# Altium schematic sheet Connectors.SchDoc(Connectors)
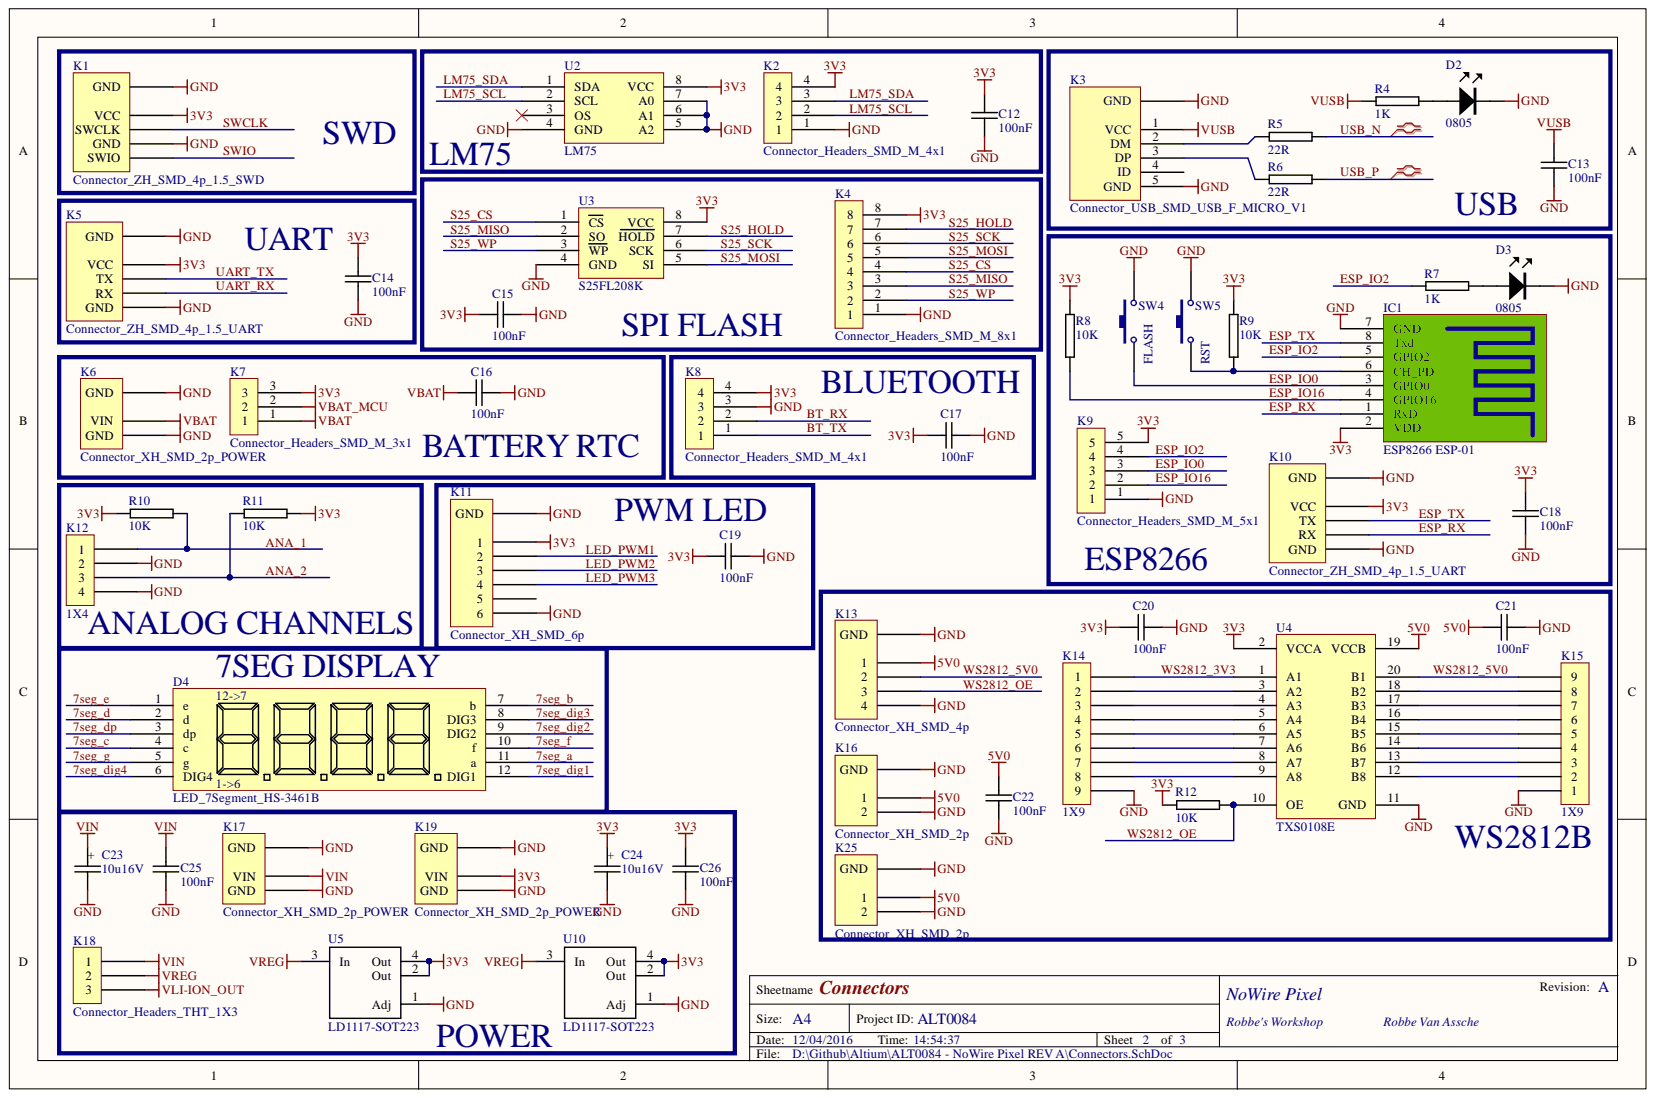
[diagram p0: Connectors.SchDoc]

## Parts
C12  100nF  [C0402]
C13  100nF  [C0402]
C14  100nF  [C0402]
C15  100nF  [C0402]
C16  100nF  [C0402]
C17  100nF  [C0402]
C18  100nF  [C0402]
C19  100nF  [C0402]
C20  100nF  [C0402]
C21  100nF  [C0402]
C22  100nF  [C0402]
C23  10u16V  [1206C+_TANTAL-10u16V]
C24  10u16V  [1206C+_TANTAL-10u16V]
C25  100nF  [C0402]
C26  100nF  [C0402]
D2  0805  [0805LED]
D3  0805  [0805LED]
D4  LED_7Segment_HS-3461B  [HS3461-TH]
IC1  ESP8266 ESP-01  [ESP8266 ESP-01]
K1  Connector_ZH_SMD_4p_1.5_SWD  [ZH_SMD_4p_1.5]
K2  Connector_Headers_SMD_M_4x1  [Header_Male_2.54 4x1_COMBO]
K3  Connector_USB_SMD_USB_F_MICRO_V1  [USB_F_Micro_V1]
K4  Connector_Headers_SMD_M_8x1  [Header_Male_2.54 8x1_COMBO]
K5  Connector_ZH_SMD_4p_1.5_UART  [ZH_SMD_4p_1.5]
K6  Connector_XH_SMD_2p_POWER  [2.54_2p_THT+SMD]
K7  Connector_Headers_SMD_M_3x1  [Header_Male_2.54 3x1_COMBO]
K8  Connector_Headers_SMD_M_4x1  [Header_Male_2.54 4x1_COMBO]
K9  Connector_Headers_SMD_M_5x1  [Header_Male_2.54 5x1_COMBO]
K10  Connector_ZH_SMD_4p_1.5_UART  [ZH_SMD_4p_1.5]
K11  Connector_XH_SMD_6p  [2.54_6p]
K12  1X4  [HEADER-1X4]
K13  Connector_XH_SMD_4p  [2.54_4p]
K14  1X9  [HEADER-1X9]
K15  1X9  [HEADER-1X9]
K16  Connector_XH_SMD_2p  [2.54_2p]
K17  Connector_XH_SMD_2p_POWER  [2.54_2p_THT+SMD]
K18  Connector_Headers_THT_1X3  [HEADER-1X3]
K19  Connector_XH_SMD_2p_POWER  [2.54_2p_THT+SMD]
K25  Connector_XH_SMD_2p  [2.54_2p]
R4  1K  [R0402]
R5  22R  [R0402]
R6  22R  [R0402]
R7  1K  [R0402]
R8  10K  [R0402]
R9  10K  [R0402]
R10  10K  [R0402]
R11  10K  [R0402]
R12  10K  [R0402]
SW4  FLASH  [1P1T_SW_5x5]
SW5  RST  [1P1T_SW_5x5]
U2  LM75  [SO8_N]
U3  S25FL208K  [SO8_N]
U4  TXS0108E  [TSSOP20]
U5  LD1117-SOT223  [SOT223]
U10  LD1117-SOT223  [SOT223]

## Nets
3V3: C12.1 C14.1 C15.1 C17.1 C18.1 C19.1 C20.1 C24.1 C26.1 IC1.2(VDD) K1.1(VCC) K2.4 K4.8 K5.1(VCC) K7.3 K8.4 K9.5 K10.1(VCC) K11.1 K19.1 R8.2 R9.2 R10.2 R11.2 R12.2 U2.8(VCC) U3.8(VCC) U4.2(VCCA) U5.2(Out) U5.4(Out) U10.2(Out) U10.4(Out)
5V0: C21.1 C22.1 K13.1 K16.1 K25.1 U4.19(VCCB)
GND: C12.2 C13.2 C14.2 C15.2 C16.2 C17.2 C18.2 C19.2 C20.2 C21.2 C22.2 C23.2 C24.2 C25.2 C26.2 D2.K D3.K IC1.7(GND) K1.0(GND) K1.3(GND) K2.1 K3.0(GND) K3.5(GND) K4.1 K5.0(GND) K5.4(GND) K6.0 K6.2 K8.3 K9.1 K10.0(GND) K10.4(GND) K11.0(GND) K11.6 K12.2 K12.4 K13.0(GND) K13.4 K14.9 K15.1 K16.0 K16.2 K17.0 K17.2 K19.0 K19.2 K25.0 K25.2 SW4.2 SW5.2 U2.4(GND) U2.5(A2) U2.6(A1) U2.7(A0) U3.4(GND) U4.11(GND) U5.1(Adj) U10.1(Adj)
VBAT: C16.1 K6.1 K7.1
VBAT_MCU: K7.2
VIN: C23.1 C25.1 K17.1 K18.1
VLI-ION_OUT: K18.3
VREG: K18.2 U5.3(In) U10.3(In)
VUSB: C13.1 K3.1(VCC) R4.1
7SEG_A: D4.11(a)
7SEG_B: D4.7(b)
7SEG_C: D4.4(c)
7SEG_D: D4.2(d)
7SEG_DIG1: D4.12(DIG1)
7SEG_DIG2: D4.9(DIG2)
7SEG_DIG3: D4.8(DIG3)
7SEG_DIG4: D4.6(DIG4)
7SEG_DP: D4.3(dp)
7SEG_E: D4.1(e)
7SEG_F: D4.10(f)
7SEG_G: D4.5(g)
ANA_1: K12.1 R10.1
ANA_2: K12.3 R11.1
BT_RX: K8.2
BT_TX: K8.1
ESP_IO0: IC1.3(GPIO0) K9.3 SW4.1
ESP_IO2: IC1.5(GPIO2) K9.4 R7.1
ESP_IO16: IC1.4(GPIO16) K9.2 R8.1
ESP_RX: IC1.1(RxD) K10.3(RX)
ESP_TX: IC1.8(Txd) K10.2(TX)
LED_PWM1: K11.2
LED_PWM2: K11.3
LED_PWM3: K11.4
LM75_SCL: K2.2 U2.2(SCL)
LM75_SDA: K2.3 U2.1(SDA)
S25_CS: K4.4 U3.1(CS)
S25_HOLD: K4.7 U3.7(HOLD)
S25_MISO: K4.3 U3.2(SO)
S25_MOSI: K4.5 U3.5(SI)
S25_SCK: K4.6 U3.6(SCK)
S25_WP: K4.2 U3.3(WP)
SWCLK: K1.2(SWCLK)
SWIO: K1.4(SWIO)
UART_RX: K5.3(RX)
UART_TX: K5.2(TX)
USB_N: R5.2
USB_P: R6.2
WS2812_3V3: K14.1 U4.1(A1)
WS2812_5V0: K13.2 K15.9 U4.20(B1)
WS2812_OE: K13.3 R12.1 U4.10(OE)
NetD2_A: D2.A R4.2
NetD3_A: D3.A R7.2
NetIC1_6: IC1.6(CH_PD) R9.1 SW5.1
NetK3_2: K3.2(DM) R5.1
NetK3_3: K3.3(DP) R6.1
NetK14_2: K14.2 U4.3(A2)
NetK14_3: K14.3 U4.4(A3)
NetK14_4: K14.4 U4.5(A4)
NetK14_5: K14.5 U4.6(A5)
NetK14_6: K14.6 U4.7(A6)
NetK14_7: K14.7 U4.8(A7)
NetK14_8: K14.8 U4.9(A8)
NetK15_2: K15.2 U4.12(B8)
NetK15_3: K15.3 U4.13(B7)
NetK15_4: K15.4 U4.14(B6)
NetK15_5: K15.5 U4.15(B5)
NetK15_6: K15.6 U4.16(B4)
NetK15_7: K15.7 U4.17(B3)
NetK15_8: K15.8 U4.18(B2)

Not connected: K3 4(ID); K11 5; U2 3(OS)

## Library data of the parts
C12-C22 C25 C26 = Passives_Capacitors_0402_TBD: CAPACITOR 0402 CERAMIC; Component Kind: Standard; Library Name: RVA_Passives_Capacitors_0402.SchLib
C23 C24 = Passives_Capacitors_Tantalum_1206C-TANTAL SIZE A-10u16V: Component Kind: Standard; Library Name: RVA_Passives_Capacitors_Tantalum.SchLib
D2 D3 = LED_SMD_0805: Component Kind: Standard; Library Name: RVA_LED_SMD_0805.SchLib
K2 K8 = Connector_Headers_SMD_M_4x1: SMD Header 4x1; Component Kind: Standard; Library Name: RVA_Connector_Headers_SMD.SchLib
K3 = Connector_USB_SMD_USB_F_MICRO_V1: MICRO USB B FEMALE; Component Kind: Standard; Library Name: RVA_Connector_USB_SMD.SchLib
K4 = Connector_Headers_SMD_M_8x1: SMD Header 8x1; Component Kind: Standard; Library Name: RVA_Connector_Headers_SMD.SchLib
K7 = Connector_Headers_SMD_M_3x1: SMD Header 3x1; Component Kind: Standard; Library Name: RVA_Connector_Headers_SMD.SchLib
K9 = Connector_Headers_SMD_M_5x1: SMD Header 5x1; Component Kind: Standard; Library Name: RVA_Connector_Headers_SMD.SchLib
K12 = Connector_Headers_THT_1X4: REEL: TH; Component Kind: Standard; Supplier 1: Digi-Key; Supplier Part Number 1: S1011E-36-ND; Library Name: RVA_Connector_Headers_THT.SchLib
K14 K15 = Connector_Headers_THT_1X9: REEL: TH; Component Kind: Standard; Supplier 1: Digi-Key; Supplier Part Number 1: S1011E-36-ND; Library Name: RVA_Connector_Headers_THT.SchLib
K18 = Connector_Headers_THT_1X3: REEL: TH; Component Kind: Standard; Supplier 1: Digi-Key; Supplier Part Number 1: S1011E-36-ND; Library Name: RVA_Connector_Headers_THT.SchLib
R4-R12 = Passives_Resistors_0402_TBD: RESISTOR 0402 VALUE TO BE DETERMINED; Component Kind: Standard; Library Name: RVA_Passives_Resistors_0402.SchLib
SW4 SW5 = Mechanical_Switch_1P1T_SMD_SW-5x5: Switch 5x5; Component Kind: Standard; Library Name: RVA_Mechanical_Switch_1P1T_SMD.SchLib
U4 = TXS0108E: 8-bit Bi-directional level-shifting voltage translator for open-drain and push-pull applications; Component Kind: Standard; Library Name: RVA_Interface_Level-Translator.SchLib
D4 = LED_7Segment_HS-3461B: Component Kind: Standard; Library Name: RVA_LED_7Segment.SchLib
IC1 = ESP8266 ESP-01: Component Kind: Standard; Description: ESP8266 ESP-01; Library Name: RVA_Communication_WIFI.SchLib
K1 = Connector_ZH_SMD_4p_1.5_SWD: Component Kind: Standard; Library Name: RVA_Connector_ZH_SMD.SchLib
K5 K10 = Connector_ZH_SMD_4p_1.5_UART: Component Kind: Standard; Library Name: RVA_Connector_ZH_SMD.SchLib
K6 K17 K19 = Connector_XH_SMD_2p_POWER: Component Kind: Standard; Library Name: RVA_Connector_XH_SMD.SchLib
K11 = Connector_XH_SMD_6p: Component Kind: Standard; Library Name: RVA_Connector_XH_SMD.SchLib
K13 = Connector_XH_SMD_4p: Component Kind: Standard; Library Name: RVA_Connector_XH_SMD.SchLib
K16 K25 = Connector_XH_SMD_2p: Component Kind: Standard; Library Name: RVA_Connector_XH_SMD.SchLib
U2 = LM75: Component Kind: Standard; Library Name: RVA_Sensor_SMD.SchLib
U3 = S25FL208K: Component Kind: Standard; Library Name: RVA_Memory_SMD.SchLib
U5 U10 = LD1117-SOT223: Component Kind: Standard; Library Name: RVA_PSU_LDO_SMD.SchLib
